# Altium schematic sheet BTS7960.SchDoc
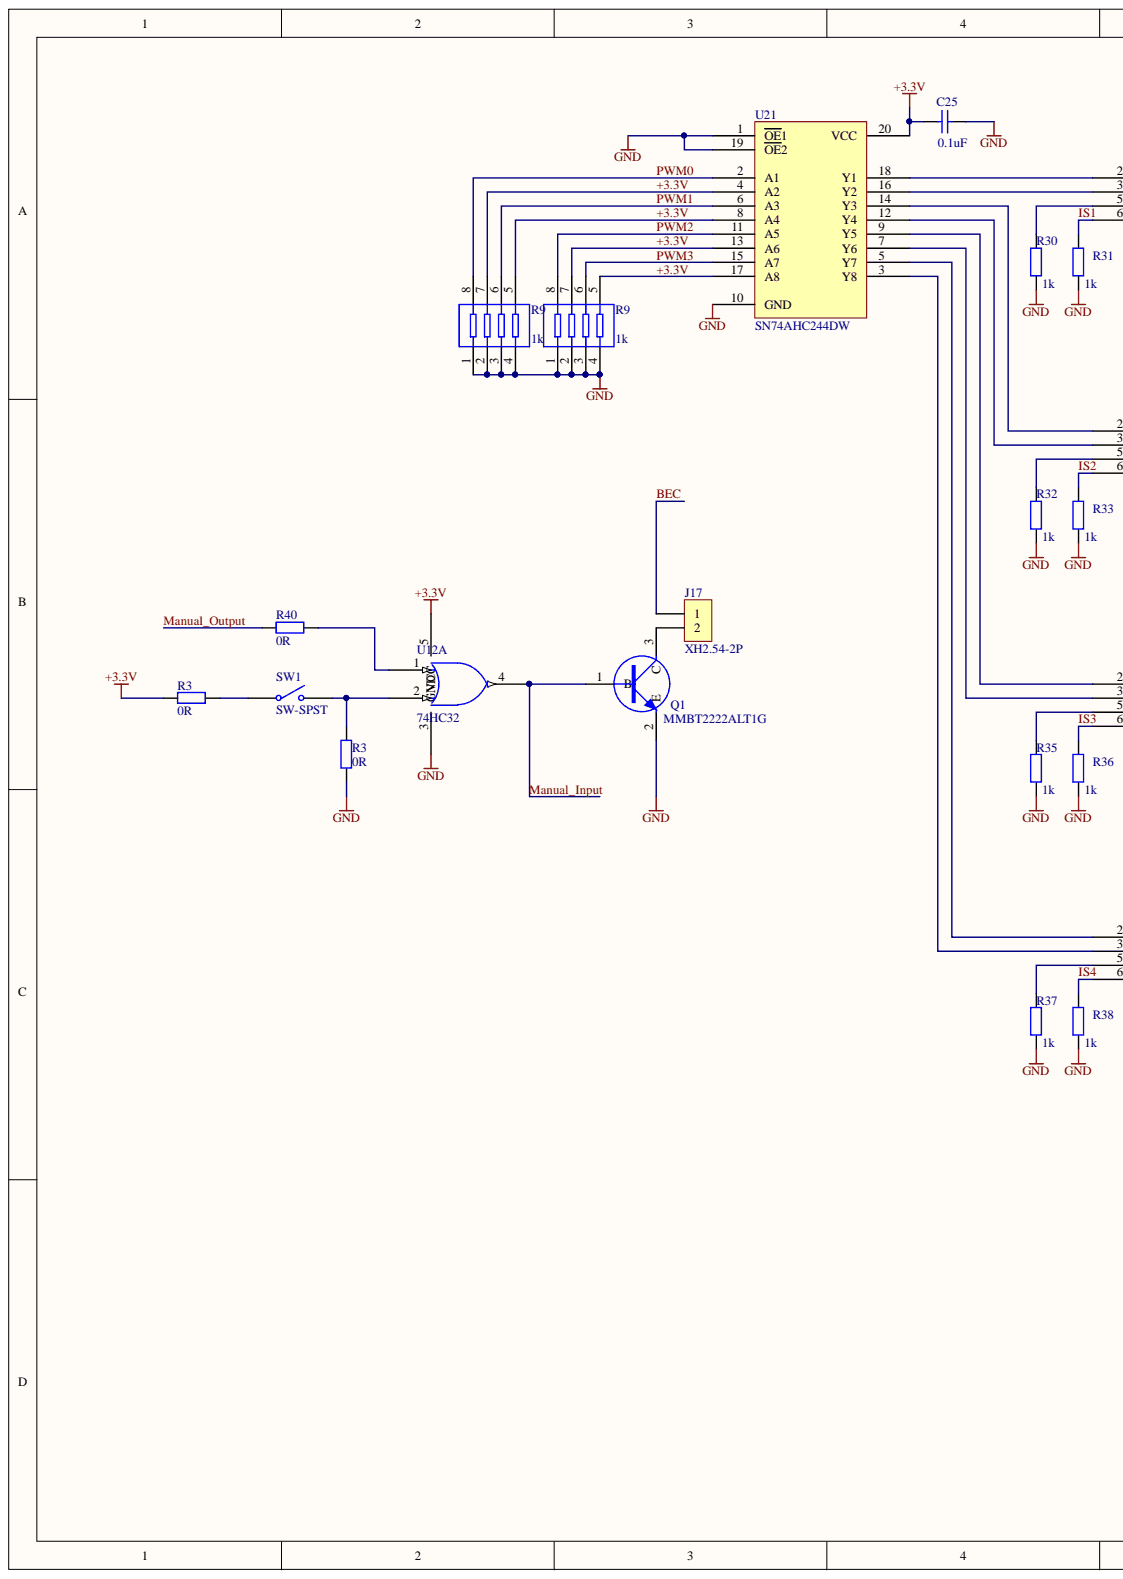
[diagram p0: BTS7960.SchDoc, left]
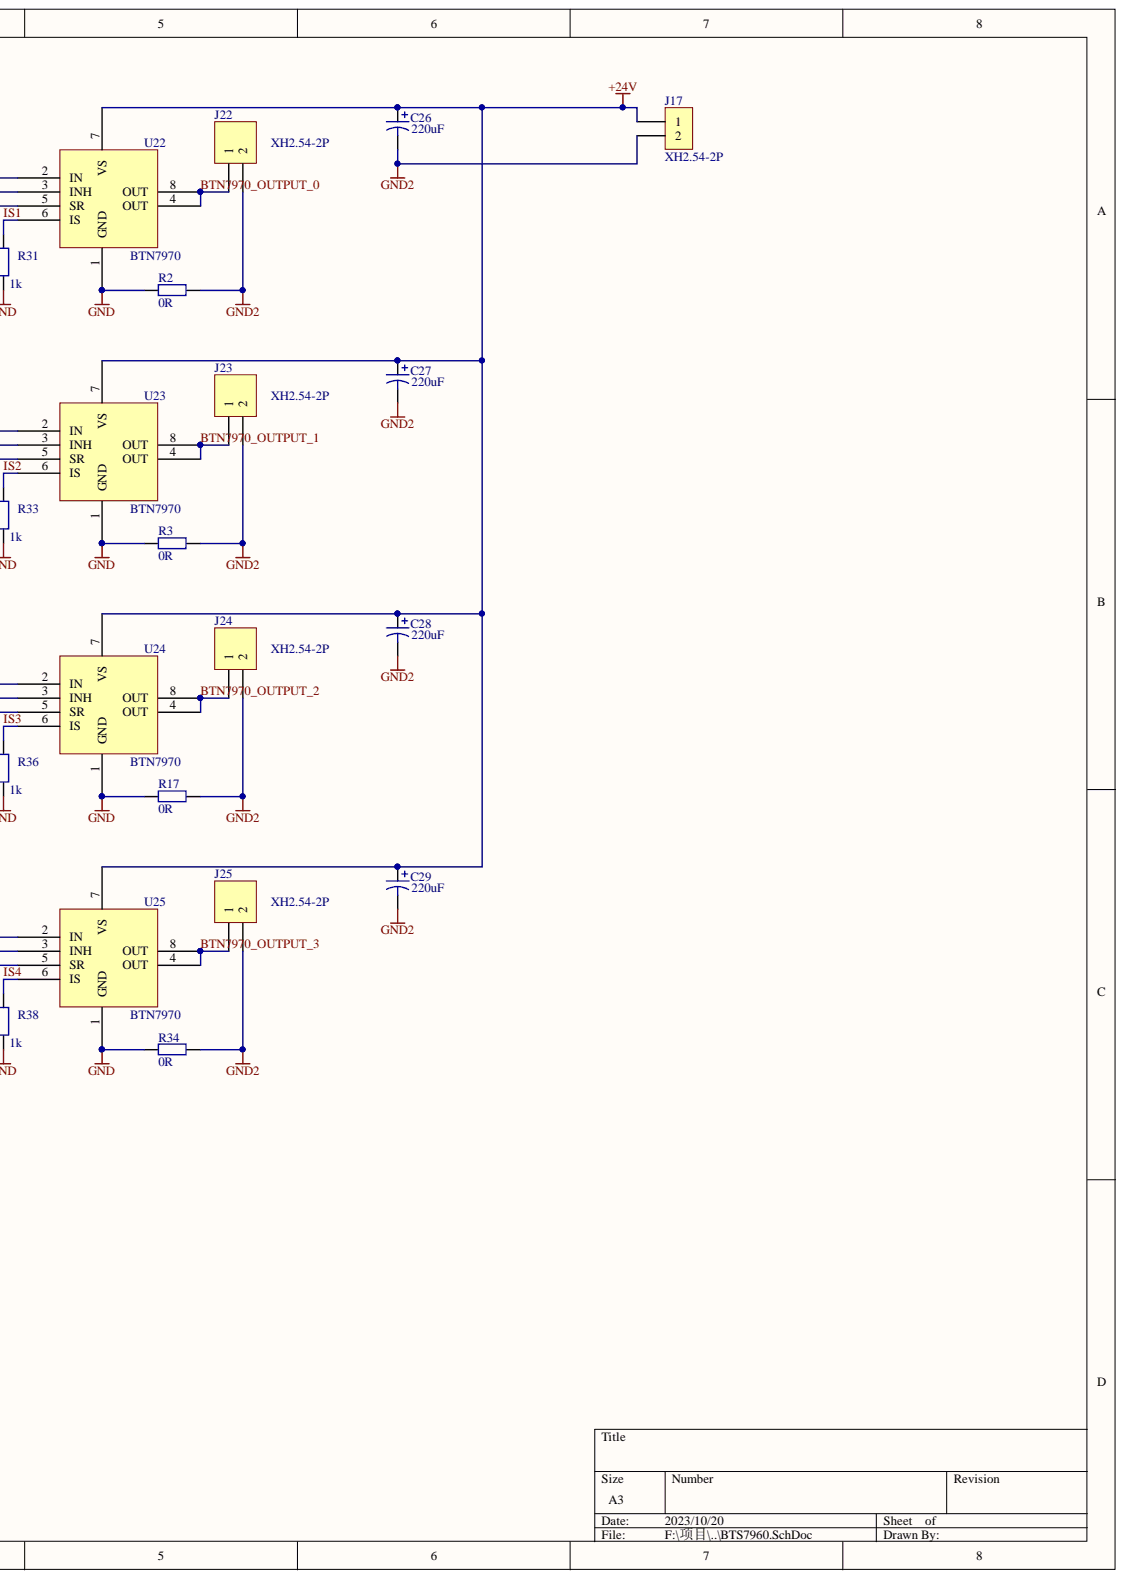
[diagram p1: BTS7960.SchDoc, right]

## Parts
C25  value 0.1uF  [CC0805]  (hidden comment: 0805-50V-0.1uF)
C26  value 220uF  [SMD-EC-F8]  (hidden comment: CD-50V-220uF-F8(SMD))
C27  value 220uF  [SMD-EC-F8]  (hidden comment: CD-50V-220uF-F8(SMD))
C28  value 220uF  [SMD-EC-F8]  (hidden comment: CD-50V-220uF-F8(SMD))
C29  value 220uF  [SMD-EC-F8]  (hidden comment: CD-50V-220uF-F8(SMD))
J17  XH2.54-2P  [XH2.54-2P]
J17  XH2.54-2P  [XH2.54-2P]
J22  XH2.54-2P  [XH2.54-2P]
J23  XH2.54-2P  [XH2.54-2P]
J24  XH2.54-2P  [XH2.54-2P]
J25  XH2.54-2P  [XH2.54-2P]
Q1  value MMBT2222ALT1G  [SOT23]  (hidden comment: MMBT2222ALT1G(SOT-23))
R2  value 0R  [0805]  (hidden comment: 0805-0Ω±1％)
R3  value 0R  [0805]  (hidden comment: 0805-0Ω±1％)
R3  value 0R  [0805]  (hidden comment: 0805-0Ω±1％)
R3  value 0R  [0805]  (hidden comment: 0805-0Ω±1％)
R9  value 1k  [SSOP16_N]  (hidden comment: Res Pack4)
R9  value 1k  [SSOP16_N]  (hidden comment: Res Pack4)
R17  value 0R  [0805]  (hidden comment: 0805-0Ω±1％)
R30  value 1k  [0805]  (hidden comment: 0805-1kΩ±1％)
R31  value 1k  [0805]  (hidden comment: 0805-1kΩ±1％)
R32  value 1k  [0805]  (hidden comment: 0805-1kΩ±1％)
R33  value 1k  [0805]  (hidden comment: 0805-1kΩ±1％)
R34  value 0R  [0805]  (hidden comment: 0805-0Ω±1％)
R35  value 1k  [0805]  (hidden comment: 0805-1kΩ±1％)
R36  value 1k  [0805]  (hidden comment: 0805-1kΩ±1％)
R37  value 1k  [0805]  (hidden comment: 0805-1kΩ±1％)
R38  value 1k  [0805]  (hidden comment: 0805-1kΩ±1％)
R40  value 0R  [0805]  (hidden comment: 0805-0Ω±1％)
SW1  SW-SPST  [SPST-2]
U12  74HC32  [SO-14]  (part A)
U21  SN74AHC244DW  [SOP-20]
U22  BTN7970  [BTN7970]
U23  BTN7970  [BTN7970]
U24  BTN7970  [BTN7970]
U25  BTN7970  [BTN7970]

## Nets
+24V: C26.1 C27.1 C28.1 C29.1 J17.1 U22.7(VS) U23.7(VS) U24.7(VS) U25.7(VS)
GND: C25.2 Q1.2 R2.2 R3.2 R9.1 R9.2 R9.3 R9.4 R17.2 R30.1 R31.1 R32.1 R33.1 R34.2 R35.1 R36.1 R37.1 R38.1 U12.3(GND) U21.1(OE1) U21.10(GND) U21.19(OE2) U22.1(GND) U23.1(GND) U24.1(GND) U25.1(GND)
GND2: C26.2 C27.2 C28.2 C29.2 J17.2 J22.2 J23.2 J24.2 J25.2 R2.1 R3.1 R17.1 R34.1
+3.3V: C25.1 R3.2 R9.5 R9.7 U12.5(VCC) U21.4(A2) U21.8(A4) U21.13(A6) U21.17(A8) U21.20(VCC)
BEC: J17.1
BTN7970_OUTPUT_0: J22.1 U22.4(OUT) U22.8(OUT)
BTN7970_OUTPUT_1: J23.1 U23.4(OUT) U23.8(OUT)
BTN7970_OUTPUT_2: J24.1 U24.4(OUT) U24.8(OUT)
BTN7970_OUTPUT_3: J25.1 U25.4(OUT) U25.8(OUT)
IS1: R31.2 U22.6(IS)
IS2: R33.2 U23.6(IS)
IS3: R36.2 U24.6(IS)
IS4: R38.2 U25.6(IS)
MANUAL_INPUT: Q1.1 U12.4
MANUAL_OUTPUT: R40.2
PWM0: R9.8 U21.2(A1)
PWM1: R9.6 U21.6(A3)
PWM2: R9.8 U21.11(A5)
PWM3: R9.6 U21.15(A7)
NetJ17_2: J17.2 Q1.3
NetR3_1: R3.1 SW1.1 SW1.2 U12.2
NetR30_2: R30.2 U22.5(SR)
NetR32_2: R32.2 U23.5(SR)
NetR35_2: R35.2 U24.5(SR)
NetR37_2: R37.2 U25.5(SR)
NetR40_1: R40.1 U12.1
NetU21_3: U21.3(Y8) U25.3(INH)
NetU21_5: U21.5(Y7) U25.2(IN)
NetU21_7: U21.7(Y6) U24.3(INH)
NetU21_9: U21.9(Y5) U24.2(IN)
NetU21_12: U21.12(Y4) U23.3(INH)
NetU21_14: U21.14(Y3) U23.2(IN)
NetU21_16: U21.16(Y2) U22.3(INH)
NetU21_18: U21.18(Y1) U22.2(IN)

## Library data of the parts
C25 = Cap: 贴片电容; Component Kind: Standard; Library Name: Miscellaneous Devices.IntLib; Signal Integrity: Cap; Simulation: CAP
C26-C29 = Cap Pol3: 贴片铝电解电容; Component Kind: Standard; Library Name: Miscellaneous Devices.IntLib; Signal Integrity: Cap Pol; Simulation: CAP
J17 J17 = CON2: 插座; Component Kind: Standard; Value: XH2.54-2P
J22-J25 = Header 2H: 插座; Component Kind: Standard; Library Name: Miscellaneous Connectors.IntLib; Signal Integrity: Connector; Value: XH2.54-2P
Q1 = S9013: 贴片三极管; Component Kind: Standard
R2 R3 R3 R3 R17 R30-R38 R40 = Res2: 贴片电阻; Component Kind: Standard; Library Name: Miscellaneous Devices.IntLib; Signal Integrity: Res; Simulation: RESISTOR
R9 R9 = Res Pack4: Isolated Resistor Network; Code_IPC: SOP65P780-16; Code_JEDEC: MO-150-AC; Component Kind: Standard; LatestRevisionDate: 29-May-2009; LatestRevisionNote: IPC-7351 Footprint Added.; Library Name: SHIELD.SCHLIB; PackageDescription: 16-Pin Plastic Small Outline Package 0.65 mm Pitch; PackageReference: SSOP16; PackageVersion: Oct-2003; Published: 24-Mar-1999; Simulation: respack_8; Publisher: Altium Limited
SW1 = SW-SPST: Single-Pole, Single-Throw Switch; Component Kind: Standard; LatestRevisionDate: 17-Jul-2002; LatestRevisionNote: Re-released for DXP Platform.; Library Name: Miscellaneous Devices.IntLib; PackageDescription: Switch; 2 Leads; PackageReference: SPST-2; Published: 24-Mar-1999; Publisher: Altium Limited
U12 = 74LS32: Component Kind: Standard
U21 = SN74AHC244DW: 集成电路; Component Kind: Standard; Library Name: Schlib2.SchLib; Value: SN74AHC244DW
U22-U25 = BTN7970: 集成电路; Component Kind: Standard; Library Name: Schlib2.SchLib; Value: BTN7970
